# Altium schematic sheet E301 Dev Board.SchDoc
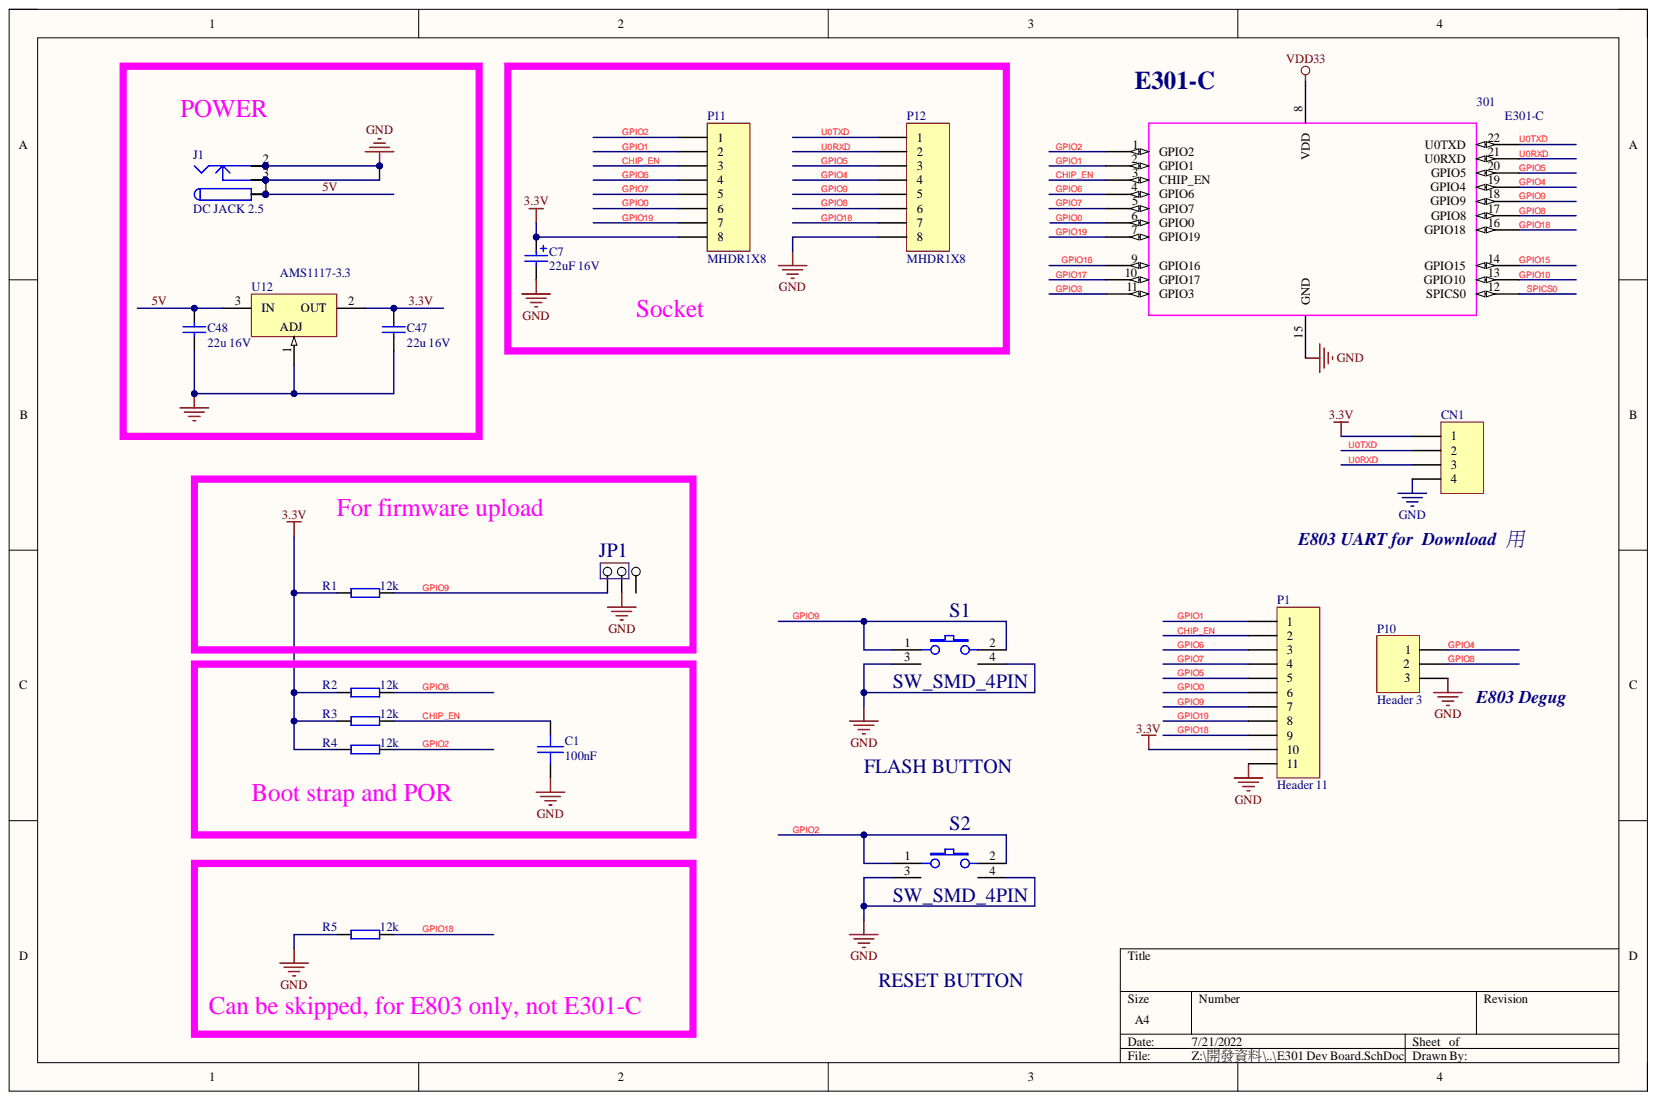
[diagram p0: E301 Dev Board.SchDoc]

## Parts
301  E301-C  [ESP-12E NO STAMP]
C1  100nF  [C0402]
C7  22uF 16V  [C0805]
C47  value 22u 16V  [C1206]  (hidden comment: Cap Semi)
C48  value 22u 16V  [C1206]  (hidden comment: Cap Semi)
CN1  Header 4  [HDR1X4]  (value not drawn)
J1  DC JACK 2.5  [PJ3EA]
JP1  (no value)  [HDR1X3]
P1  Header 11  [HDR1X11]
P10  Header 3  [HDR1X3]
P11  MHDR1X8  [Header_1x8_2mm]
P12  MHDR1X8  [Header_1x8_2mm]
R1  12k  [r0402]
R2  12k  [r0402]
R3  12k  [r0402]
R4  12k  [r0402]
R5  12k  [r0402]
S1  SW_SMD_4PIN  [TS-1102W]
S2  SW_SMD_4PIN  [TS-1102W]
U12  AMS1117-3.3  [SOT223]

## Nets
GND: 301.15(GND) C1.2 C7.2 C47.1 C48.1 CN1.4 J1.2 J1.2A J1.3 J1.3A JP1.2 P1.11 P10.3 P12.8 R5.1 S1.3 S1.4 S2.3 S2.4 U12.1
VDD33: 301.8(VDD)
3.3V: C7.1 C47.2 CN1.1 P1.10 P11.8 R1.1 R2.1 R3.1 R4.1 U12.2
5V: C48.2 J1.1 J1.1A U12.3
CHIP_EN: 301.3(CHIP_EN) C1.1 P1.2 P11.3 R3.2
GPIO0: 301.6(GPIO0) P1.6 P11.6
GPIO1: 301.2(GPIO1) P1.1 P11.2
GPIO2: 301.1(GPIO2) P11.1 R4.2 S2.1 S2.2
GPIO3: 301.11(GPIO3)
GPIO4: 301.19(GPIO4) P10.1 P12.4
GPIO5: 301.20(GPIO5) P1.5 P12.3
GPIO6: 301.4(GPIO6) P1.3 P11.4
GPIO7: 301.5(GPIO7) P1.4 P11.5
GPIO8: 301.17(GPIO8) P10.2 P12.6 R2.2
GPIO9: 301.18(GPIO9) JP1.1 P1.7 P12.5 R1.2 S1.1 S1.2
GPIO10: 301.13(GPIO10)
GPIO15: 301.14(GPIO15)
GPIO16: 301.9(GPIO16)
GPIO17: 301.10(GPIO17)
GPIO18: 301.16(GPIO18) P1.9 P12.7 R5.2
GPIO19: 301.7(GPIO19) P1.8 P11.7
SPICS0: 301.12(SPICS0)
U0RXD: 301.21(U0RXD) CN1.3 P12.2
U0TXD: 301.22(U0TXD) CN1.2 P12.1

Not connected: JP1 3

## Library data of the parts
C1 = SMT_C_0402: Surface mount capacitor 0402; Component Kind: Standard; Library Name: Vowstar_Basic_Device.IntLib
C7 = Cap Pol1_1: Polarized Capacitor (Radial); Value: 10uF; Component Kind: Standard; Library Name: MCD_TOOLS.SchLib; Published: 8-Jun-2000; Revision: July-2002: Re-released for DXP Platform.; Signal Integrity: C200M; Simulation: CAP; Publisher: Altium Limited
C47 = Cap Semi: Capacitor (Semiconductor SIM Model); Component Kind: Standard; LatestRevisionDate: 17-Jul-2002; LatestRevisionNote: Re-released for DXP Platform.; Library Name: Miscellaneous Devices.IntLib; PackageReference: C1206; Published: 8-Jun-2000; Signal Integrity: Cap Semi; Simulation: CAP; Publisher: Altium Limited
C48 = Cap Semi: Capacitor (Semiconductor SIM Model); Value: 22u 16V; Component Kind: Standard; LatestRevisionDate: 17-Jul-2002; LatestRevisionNote: Re-released for DXP Platform.; Library Name: Miscellaneous Devices.IntLib; PackageReference: C1206; Published: 8-Jun-2000; Signal Integrity: Cap Semi; Simulation: CAP; Publisher: Altium Limited
CN1 = Header 4: Header, 4-Pin; Component Kind: Standard; Library Name: MB1134N.SCHLIB; Revision: 17-Jul-2002: Re-released for DXP Platform.; Signal Integrity: Connector; Publisher: Altium Limited
J1 = PWR2.5: Low Voltage Power Supply Connector; Component Kind: Standard; ComponentLink1Description: Manufacturer Link; ComponentLink1URL: http://domino.kycon.com/internet/Products.html; DatasheetDocument: 09-May-2002; Published: 17-Dec-2003; Library Name: E803 TEST FIXTUNE.SCHLIB; Publisher: Altium Limited
JP1 = JP3: Component Kind: Standard; Library Name: MB1134N.SCHLIB
P1 = Header 11: Header, 11-Pin; Component Kind: Standard; LatestRevisionDate: 17-Jul-2002; LatestRevisionNote: Re-released for DXP Platform.; Library Name: Miscellaneous Connectors.IntLib; Signal Integrity: Connector; Publisher: Altium Limited
P10 = Header 3: Header, 3-Pin; Component Kind: Standard; LatestRevisionDate: 17-Jul-2002; LatestRevisionNote: Re-released for DXP Platform.; Library Name: Miscellaneous Connectors.IntLib; Publisher: Altium Limited
P11 P12 = MHDR1X8: Header, 8-Pin; Component Kind: Standard; LatestRevisionDate: 17-Jul-2002; LatestRevisionNote: Re-released for DXP Platform.; Library Name: Miscellaneous Connectors.IntLib; Signal Integrity: Connector; Publisher: Altium Limited
R1-R5 = SMT_R_0402: Surface mount resistor 0402; Component Kind: Standard; Library Name: Vowstar_Basic_Device.IntLib
S1 S2 = SW_DIP_4PIN_90: Component Kind: Standard; Library Name: MB1134N.SCHLIB
U12 = RT9164A: !A Fixed and Adjustable LDO; Manufacturer: National Semiconductor; PartNumber: JL137BXA; CompType: LDO IC; PackageTopMarking: NS ZSSXXYYA 27014 Q*JM38510/11803BXA; Code_JEDEC: TO-39; Component Kind: Standard; ComponentLink1Description: LM137 Datasheet; ComponentLink1URL: http://www.national.com/ds/LM/LM137.pdf; ComponentLink2Description: LM137 Web Page; ComponentLink2URL: http://www.national.com/pf/LM/LM137.html; ComponentLink3Description: H03A Package Page; ComponentLink3URL: http://www.national.com/packaging/folders/h03a.html; DatasheetVersion: Nov-2004; Library Name: Control.SchLib; PackageDescription: Metal Can, TO-39, 3 Lead, .200in Dia, P.C.; PackageReference: H03A; PackageVersion: revD, Feb-1995; PinCount: 3; Published: 4-Dec-2008; RoHS: N; Publisher: Altium Limited
301 = E301-C: Component Kind: Standard; Library Name: E301.SchLib
